# Altium schematic sheet Schematic_Capstone.SchDoc
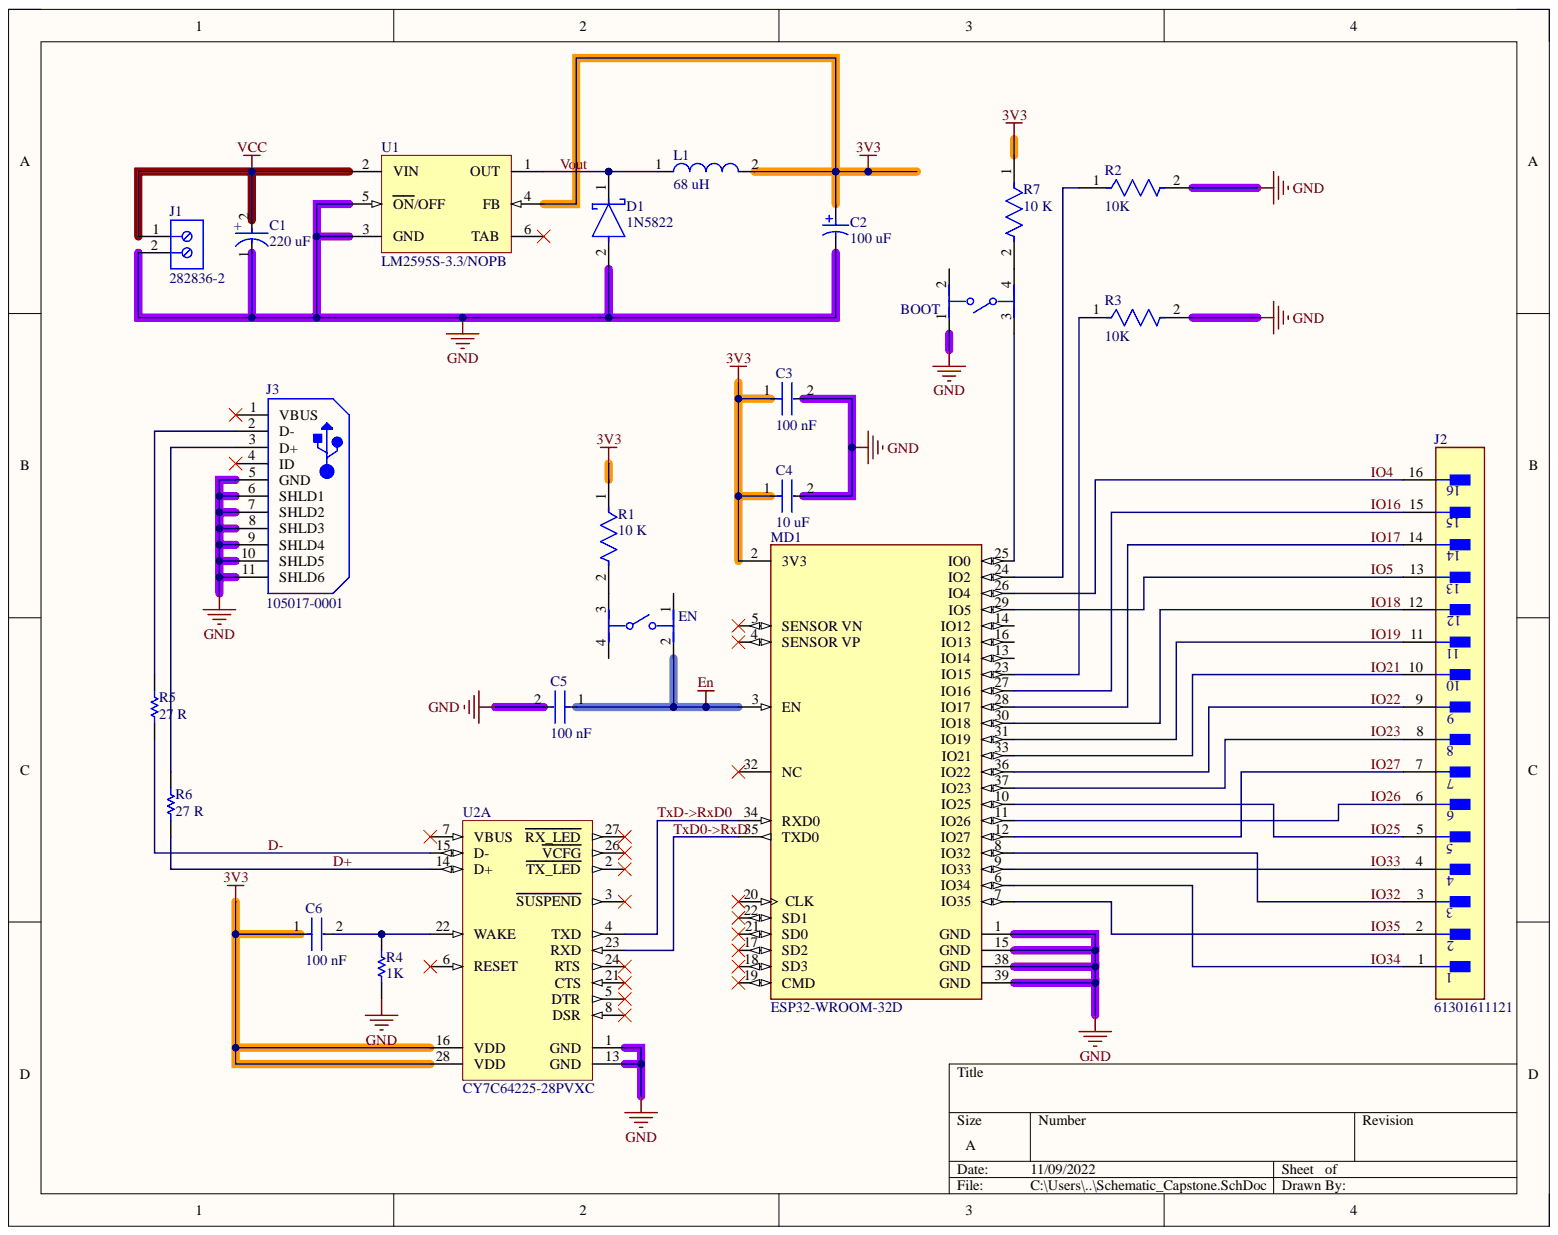
[diagram p0: Schematic_Capstone.SchDoc]

## Parts
BOOT  PTS645SM43SMTR92LFS  [FP-PTS645SM43SMTR92LFS-MFG]  (value not drawn)
C1  220 uF  [FP-860010473011-MFG]
C2  100 uF  [VISH-7343-31_N]
C3  100 nF  [FP-C0805C-DN-MFG]
C4  10 uF  [FP-C3225-250-0_45-MFG]
C5  100 nF  [FP-C0805C-DN-MFG]
C6  100 nF  [FP-C0805C-DN-MFG]
D1  1N5822  [FP-DO-201AD-MFG]
EN  PTS645SM43SMTR92LFS  [FP-PTS645SM43SMTR92LFS-MFG]  (value not drawn)
J1  282836-2  [TECO-282836-2_V]
J2  61301611121  [FP-61301611121-MFG]
J3  105017-0001  [USB-MICRO-B_V]
L1  68 uH  [WE-PD-L]
MD1  ESP32-WROOM-32D  [FP-ESP32-WROOM-32D-MFG]
R1  10 K  [FP-CRCW2010-e3-IPC_B]
R2  10K  [FP-CRCW2010-e3-IPC_B]
R3  10K  [FP-CRCW2010-e3-IPC_B]
R4  1K  [RESC1005X40X25NL05T05]
R5  27 R  [RESC3216X70X50NL05T20]
R6  27 R  [RESC3216X70X50NL05T20]
R7  10 K  [FP-CRCW2010-e3-IPC_B]
U1  LM2595S-3.3/NOPB  [KTT0005B_N]
U2  CY7C64225-28PVXC  [CYP-PVX28_L]  (part A)

## Nets
3V3: C2.1 C3.1 C4.1 C6.1 L1.2 MD1.2(3V3) R1.1 R7.1 U1.4(FB) U2.16(VDD) U2.28(VDD)
En: C5.1 EN.2 MD1.3(EN)
GND: BOOT.1 C1.1 C2.2 C3.2 C4.2 C5.2 D1.2 J1.2 J3.5(GND) J3.6(SHLD1) J3.7(SHLD2) J3.8(SHLD3) J3.9(SHLD4) J3.10(SHLD5) J3.11(SHLD6) MD1.1(GND) MD1.15(GND) MD1.38(GND) MD1.39(GND) R2.2 R3.2 R4.2 U1.3(GND) U1.5(ON/OFF) U2.1(GND) U2.13(GND)
VCC: C1.2 J1.1 U1.2(VIN)
D+: R6.1 U2.14(D+)
D-: R5.2 U2.15(D-)
IO4: J2.16 MD1.26(IO4)
IO5: J2.13 MD1.29(IO5)
IO16: J2.15 MD1.27(IO16)
IO17: J2.14 MD1.28(IO17)
IO18: J2.12 MD1.30(IO18)
IO19: J2.11 MD1.31(IO19)
IO21: J2.10 MD1.33(IO21)
IO22: J2.9 MD1.36(IO22)
IO23: J2.8 MD1.37(IO23)
IO25: J2.5 MD1.10(IO25)
IO26: J2.6 MD1.11(IO26)
IO27: J2.7 MD1.12(IO27)
IO32: J2.3 MD1.8(IO32)
IO33: J2.4 MD1.9(IO33)
IO34: J2.1 MD1.6(IO34)
IO35: J2.2 MD1.7(IO35)
TxD0->RxD: MD1.35(TXD0) U2.23(RXD)
TxD->RxD0: MD1.34(RXD0) U2.4(TXD)
Vout: D1.1 L1.1 U1.1(OUT)
NetBOOT_3: BOOT.3 MD1.25(IO0)
NetBOOT_4: BOOT.4 R7.2
NetC6_2: C6.2 R4.1 U2.22(WAKE)
NetEN_3: EN.3 R1.2
NetJ3_2: J3.2(D-) R5.1
NetJ3_3: J3.3(D+) R6.2
NetMD1_23: MD1.23(IO15) R3.1
NetMD1_24: MD1.24(IO2) R2.1

Not connected: BOOT 2; EN 1 4; J3 1(VBUS) 4(ID); MD1 4(SENSOR_VP) 5(SENSOR_VN) 13(IO14) 14(IO12) 16(IO13) 17(SD2) 18(SD3) 19(CMD) 20(CLK) 21(SD0) 22(SD1) 32(NC); U1 6(TAB); U2 2(TX_LED) 3(SUSPEND) 5(DTR) 6(RESET) 7(VBUS) 8(DSR) 21(CTS) 24(RTS) 26(VCFG) 27(RX_LED)

## Library data of the parts
BOOT EN = CMP-2000-05160-2: SWITCH TACTILE SPST-NO 0.05A 12V; Actuator Length: 0.85mm; Actuator Material: Nylon; Actuator Type: Standard; Contact Resistance: 100mR; Current Rating: 50mA; Illumination Type: Non-Illuminated; Mechanical Life: 100000Cycles; Operating Force: 160gf; Switch Function: Off-Mom; Throw Configuration: SPST; Case/Package: SMD/SMT; Color: Black; Component Kind: Standard; Contact Material: Silver; Contact Plating: Silver; Depth: 9mm; Lead Free: Lead Free; Length: 6mm; Library Name: Altium Content Vault; Max Current Rating: 50mA; Max Operating Temperature: 60°C; Max Voltage Rating (DC): 12V; Min Operating Temperature: -20°C; Mount: Surface Mount; Orientation: Straight; Package Quantity: 1; Packaging: Tape and Reel; REACH SVHC: No SVHC; Termination: Gull Wing; Voltage Rating (DC): 12V
C1 = CMP-207834-000007-1: CAP 220 UF 20% 25 V; Capacitance: 220uF; Life (Hours): 2000Hour; Material: Aluminium; Polarity: Polar; Ripple Current: 310mA; Voltage Rating: 25V; Case/Package: Radial; Component Kind: Standard; Diameter: 6.3mm; Height: 11mm; Height - Seated (Max): 0.492inch; Lead Pitch: 0.098inch; Library Name: Altium Content Vault; Max Operating Temperature: 85°C; Min Operating Temperature: -40°C; Mount: Through Hole; Package Quantity: 1500; Packaging: Tape and Reel; RoHS Compliant: Yes; Series: WCAP-ATG8; Tolerance: 20%; Voltage Rating (DC): 25V
C2 = CMP-1666-00024-1: Solid Tantalum Chip Capacitor, 100 uF, +/- 10%, 10 V, -55 to 125 degC, 2917 (7343 Metric), RoHS, Tape and Reel; Case\Package: 0603; Power Rating: 100 mW; Resistance: 1.2 kohm; Tolerance: 1%; Voltage Rating: 50 V; Case Code (Imperial): 0603; Case Code (Metric): 1608; Component Kind: Standard; ComponentLink1Description: Manufacturer URL; ComponentLink1URL: http://www.vishay.com/; ComponentLink2Description: Datasheet; ComponentLink2URL: http://www.vishay.com/docs/40002/293d.pdf; DatasheetVersion: Aug-16; Height: 0.45 mm; Length: 1.6 mm; Library Name: Altium Content Vault; Max Operating Temperature: 155 degC; Min Operating Temperature: -55 degC; Mount: Surface Mount; Package Quantity: 200; PackageDescription: 2-Pin Surface Mount Device, Body 7.3 x 4.3 mm; PackageReference: 7343-31; Packaging: Tape and Reel; Pins: 2; REACH SVHC: No SVHC; RoHSCompliant: true; Voltage Rating (DC): 50 V; Width: 0.8 mm
C3 C5 C6 = CMP-2007-00279-2: CAP CER 0.1UF 50V X7R 0805; Manufacturer: KEMET; Manufacturer Part Number: C0805C104K5RACTU; Capacitance: 0.10 μF; Material: Ceramic; Temperature Coefficient: 30ppm/°C; Tolerance: 0.1; Value: 0.10 μF; Automotive: No; Category: Cap; Component Kind: Standard; ComponentLink2Description: Datasheet; ComponentLink2URL: https://content.kemet.com/datasheets/KEM_C1003_C0G_SMD.pdf; Device Class L1: Passive Components; Device Class L2: Capacitors; Device Class L3: Ceramic Capacitors; Digikey Description: CAP CER 0.1UF 50V X7R 0805; Height: 0.88mm; Ipc Land Pattern Name: CAPC200125X78; Lead Free: Yes; Library Name: Altium Content Vault; Mouser Description: Cap Ceramic 0.1uF 50V X7R 10% SMD 2.0 x 1.2 mm 125 C Paper T/R; Octopart: https://octopart.com/c0805c104k5ractu-kemet-143926; Package: C0805C-DN; RoHS: TRUE; Temperature: -55°C to +125°C; Temperature Characteristic: X7R; Temperature Range High: +125°C; Temperature Range Low: -55°C; Voltage Rating: 50 V
C4 = CMP-08246-007262-1: COMMERCIAL GRADE GENERAL PURPOSE; Component Kind: Standard; Library Name: Altium Content Vault
D1 = CMP-12187-000025-1: DIODE SCHOTTKY 40V 3A DO201AD; Manufacturer: STMicroelectronics; Max Junction Temp: +150°C; Max Surge Forward Current: 80A; Peak Reverse Current: 2mA; Automotive: No; Category: Diode; Component Kind: Standard; ComponentLink2Description: Datasheet; ComponentLink2URL: https://www.st.com/resource/en/datasheet/1n5822.pdf; Device Class L1: Discrete Semiconductors; Device Class L2: Diodes; Device Class L3: Schottky Diodes; Digikey Description: DIODE SCHOTTKY 40V 3A DO201AD; Forward Current: 3A; Height: 5.3mm; Lead Free: Yes; Library Name: Altium Content Vault; Manufacturer Part Number: 1N5822; Max Repetitive Reverse Voltage: 40V; Mouser Description: Schottky Diodes & Rectifiers Vr/40V Io/3A BULK; Octopart: https://octopart.com/1n5822-stmicroelectronics-323225; Package: DO-201AD; RoHS: TRUE; Temperature: to +150°C; Temperature Range High: +150°C
J1 = CMP-1684-00017-1: Female Header, Pitch 5 mm, 1 x 2 Position, Height 10 mm, Tail Length 3.5 mm, Rohs, Bulk; Manufacturer: TE Connectivity; 3D Model: 282836-2.pdf; Clamp Material - Plating: Brass-NickelPlated; Current: 13.5A; Dynamic Catalog: BuchananSeries; Featured Product: EurostyleTerminalBlockConnectors; Mating Orientation: HorizontalwithBoard; Number of Levels: 1; Other Names: 5ULV-02B  A98076; Pitch: 0.197"(5.00mm); Positions Per Level: 2; Product Training Modules: Wire-to-BoardEurostyleTerminalBlocks; Screw Thread: M3; Torque - Screw: 0.68Nm(6Lb-In); Voltage: 300V; Wire Gauge: 16-30AWG; Wire Termination: Screw-RisingCageClamp; Category: Connectors,Interconnects; Color: Green; Component Kind: Standard; Contact Material - Plating: Brass-TinPlated; Datasheet URL: http://www.te.com.cn/commerce/DocumentDelivery/DDEController?Action=showdoc&DocId=Catalog+Section%7F1308389_EUROSTYLE_TERMINAL_BLOCKS%7F0607%7Fpdf%7FEnglish%7FENG_CS_1308389_EUROSTYLE_TERMINAL_BLOCKS_0607.pdf%7F282836-2; Family: TerminalBlocks-WiretoBoard; Features: Interlocking(Side); Housing Material: Polyamide(PA66),Nylon6/6; Library Name: Altium Content Vault; Manufacturer URL: http://www.te.com; Mounting Type: ThroughHole; Package Description: Female Header, Pitch 5 mm, 1 x 2 Position, Height 10 mm, Tail Length 3.5 mm; Package Reference: THD; Packaging: Bulk; RoHS Information: 282836-2StatementofCompliance; Screw Material - Plating: Brass-NickelPlated; Series: Buchanan; Standard Package: 300
J2 = CMP-1502-01095-2: CONN HEADER VERT 16POS 2.54MM; Gender: Male; Number of Contacts: 16; Pitch: 0.1inch; Voltage Rating: 250V; Color: Black; Component Kind: Standard; Connector Type: Header; Contact Finish - Mating: GOLD; Contact Material: Copper Alloy; Contact Mating Length: 0.236inch; Contact Plating: Gold; Contact Shape: Square; Contact Type: Male Pin; Fastening Type: Pull; Flammability Rating: UL94 V-0; Insulation Color: Black; Insulation Material: Nylon; Library Name: Altium Content Vault; Max Operating Temperature: 105°C; Min Operating Temperature: -40°C; Mount: Through Hole; Number of Positions: 16; Number of Rows: 1; Packaging: Bulk; RoHS Compliant: Yes; Series: WR-PHD; Termination: Solder
J3 = CMP-2000-05827-1: Micro-USB B Receptacle, Right Angle, Bottom Mount, Surface Mount, with Solder Tabs, -30 to 85 degC, 5-Pin USB, RoHS, Tape and Reel; Manufacturer: Molex; 3D Model: 105017-0001.stp; Catalog Drawings: 1050170001; Circuits: 5; Connector Type: USB-microB; Featured Product: Micro-USBConnectorsUSBConnectors; Mating Products: WM17145-ND-CABLEMICROUSBBTOSTDA1.0MWM17147-ND-CABLEMICROUSBBTOSTDA2.0MWM17146-ND-CABLEMICROUSBBTOSTDA1.5M; Online Catalog: Molex-MicroUSB; Other Names: WM1399DKR; Package Version: Rev. F, 12/2012; PCN Assembly/Origin: ResinSupplier21/Oct/2015; Voltage - Max: 30V; Category: Connectors,Interconnects; Component Kind: Standard; Datasheet URL: http://www.molex.com/elqNow/elqRedir.htm?ref=http://www.molex.com/webdocs/datasheets/pdf/en-us/1050170001_IO_CONNECTORS.pdf; Datasheet Version: 03/2016; Family: USB,DVI,HDMIConnectors; Features: Shielded,SolderRetention; Gender: Receptacle; Ingress Protection: -; Library Name: Altium Content Vault; Manufacturer URL: http://www.molex.com/; Mounting Technology: SurfaceMount; Mounting Type: BoardCutout,SurfaceMount,RightAngle; Number of Contacts: 5; Package Description: 5-Leads USB, Micro B, Body 7.5 x 5 mm, Pitch 0.65 mm; Package Reference: MICRO-B; Package URL: http://www.molex.com/pdm_docs/sd/1050170001_sd.pdf; Packaging: Digi-Reel®; RoHS Information: 1050170001CertofCompliance; Series: -; Specifications: -; Standard Package: 1
L1 = CMP-0227-00736-1: SMD-Shielded Power Inductor WE-PD, L = 68.0 µH; Inductance: 68.0 ÂµH; Component Kind: Standard; ComponentLink1Description: Manufacturer Link; ComponentLink1URL: http://www.we-online.com; ComponentLink2Description: Datasheet; ComponentLink2URL: http://www.we-online.de/katalog/media/pdf/744771168.pdf; DatasheetVersion: ver 6, Jun-2005; Library Name: Altium Content Vault; PackageDescription: SMD Inductor, 2-Leads, Body 12.0 x 12.0 mm; PackageReference: WE-PD-L; PackageVersion: 2006
MD1 = CMP-194065-000003-1: WIFI MODULE 32MBITS SPI FLASH; Manufacturer: Espressif Systems; Bandwidth: 72MHz; Data Rate: 150Mbits/s; Interface: I2C,SPI,UART,Ethernet,I2S,Other; Max Frequency: 2484MHz; Max Supply Voltage: 3.6V; Min Supply Voltage: 3V; Nominal Supply Current: 500mA; Number Of Adc Channels: 16; Number Of Gpio: 32; Sensitivity: -98dBm; Automotive: No; Category: UNK; Component Kind: Standard; ComponentLink2Description: Datasheet; ComponentLink2URL: https://www.espressif.com/sites/default/files/documentation/esp32-wroom-32d_esp32-wroom-32u_datasheet_en.pdf; Device Class L1: Integrated Circuits (ICs); Device Class L2: RF Semiconductors and Devices; Device Class L3: Transceivers; Digikey Description: WIFI MODULE 32MBITS SPI FLASH; Height: 3.2mm; Lead Free: Yes; Library Name: Altium Content Vault; Manufacturer Part Number: ESP32-WROOM-32D; Mouser Description: Wi-Fi/BT BLE Module ESP32-D0WD, SPI Flash, UART, P; Octopart: https://octopart.com/esp32-wroom-32d-espressif+systems-91040050; Package: ESP32-WROOM-32D; RoHS: TRUE; Temperature: -40°C to +85°C; Temperature Range High: +85°C; Temperature Range Low: -40°C
R1-R3 R7 = CMP-1017-00623-2: RES Thick Film, 10kΩ, 1%, 0.75W, 100ppm/°C, 2010; Power Rating: 750mW; Resistance: 10kR; Voltage Rating: 400V; Case Code (Imperial): 2010; Case Code (Metric): 5025; Case/Package: 2010; Component Kind: Standard; Composition: Thick Film; Contact Plating: Tin; Depth: 2.5mm; Height: 0.6mm; Lead Free: Lead Free; Length: 5mm; Library Name: Altium Content Vault; Max Operating Temperature: 155°C; Military Standard: Not; Min Operating Temperature: -55°C; Package Quantity: 4000; Packaging: Tape and Reel; Radiation Hardening: No; Temperature Coefficient: 100ppm/°C; Termination: SMD/SMT; Tolerance: 1%; Voltage Rating (DC): 400V; Weight: 0.000899oz; Width: 2.5mm
R4 = CMP-2002-00432-1: Manufacturer: Panasonic; Part Number: ERJ-2GEJ102X; Component Kind: Standard; Library Name: Altium Content Vault
R5 R6 = CMP-2003-00830-1: Manufacturer: Panasonic; Part Number: ERJ8ENF27R0V; Component Kind: Standard; Library Name: Altium Content Vault
U1 = CMP-0069-00229-3: SIMPLE SWITCHER® Power Converter 150 KHz 1A Step-Down Voltage Regulator, 5-pin TO-263, Pb-Free; Manufacturer: Texas Instruments; PackageTopMarking: NSUZXYTTE#*LM2595S*-3.3 P+; PowerWise: N; Code_JEDEC: MO-169-AB; Component Kind: Standard; ComponentLink1Description: Datasheet URL; ComponentLink1URL: http://www.ti.com/general/docs/lit/getliterature.tsp?genericPartNumber=LM2595&fileType=pdf; CompType: Switcher; Library Name: Altium Content Vault; PackageDescription: TO-263, Molded, .400 x .390 x .180in, 5 Lead, .067in Pitch, Surface Mount; PackageReference: KTT0005B; PinCount: 5; RoHS: Y
U2 = CMP-0967-00143-1: USB-to-UART Bridge Controller, 3 to 5.25 V, 0 to 70 degC, 28-Pin SSOP (PVX28), Tube; Manufacturer: Cypress; Max. Operating Voltage (V): 5.25; Memory Architecture: Flash; Min. Operating Voltage (V): 3; Component Kind: Standard; ComponentLink1Description: Manufacturer URL; ComponentLink1URL: http://www.cypress.com/; ComponentLink2Description: Datasheet; ComponentLink2URL: http://www.cypress.com/?docID=48153; ComponentLink3Description: Package Specification; ComponentLink3URL: http://www.cypress.com/?docID=1371; DatasheetVersion: Rev. *D; Library Name: Altium Content Vault; Max. Operating Temp. (degreesC): 70; Min. Operating Temp. (degreesC): 0; Mounting Technology: Surface Mount; PackageDescription: 28-Pin Super Small Outline Package, Body 10.2 x 5.3 mm, Pitch 0.65 mm; PackageReference: PVX28; PackageVersion: Rev. *C, 10/2001; Packing: Tube; PartNumber: CY7C64225-28PVXC; RoHS: Pb-Free
